# Altium schematic sheet AN-DGX - RN42.SchDoc("AN-DGX - RN42")
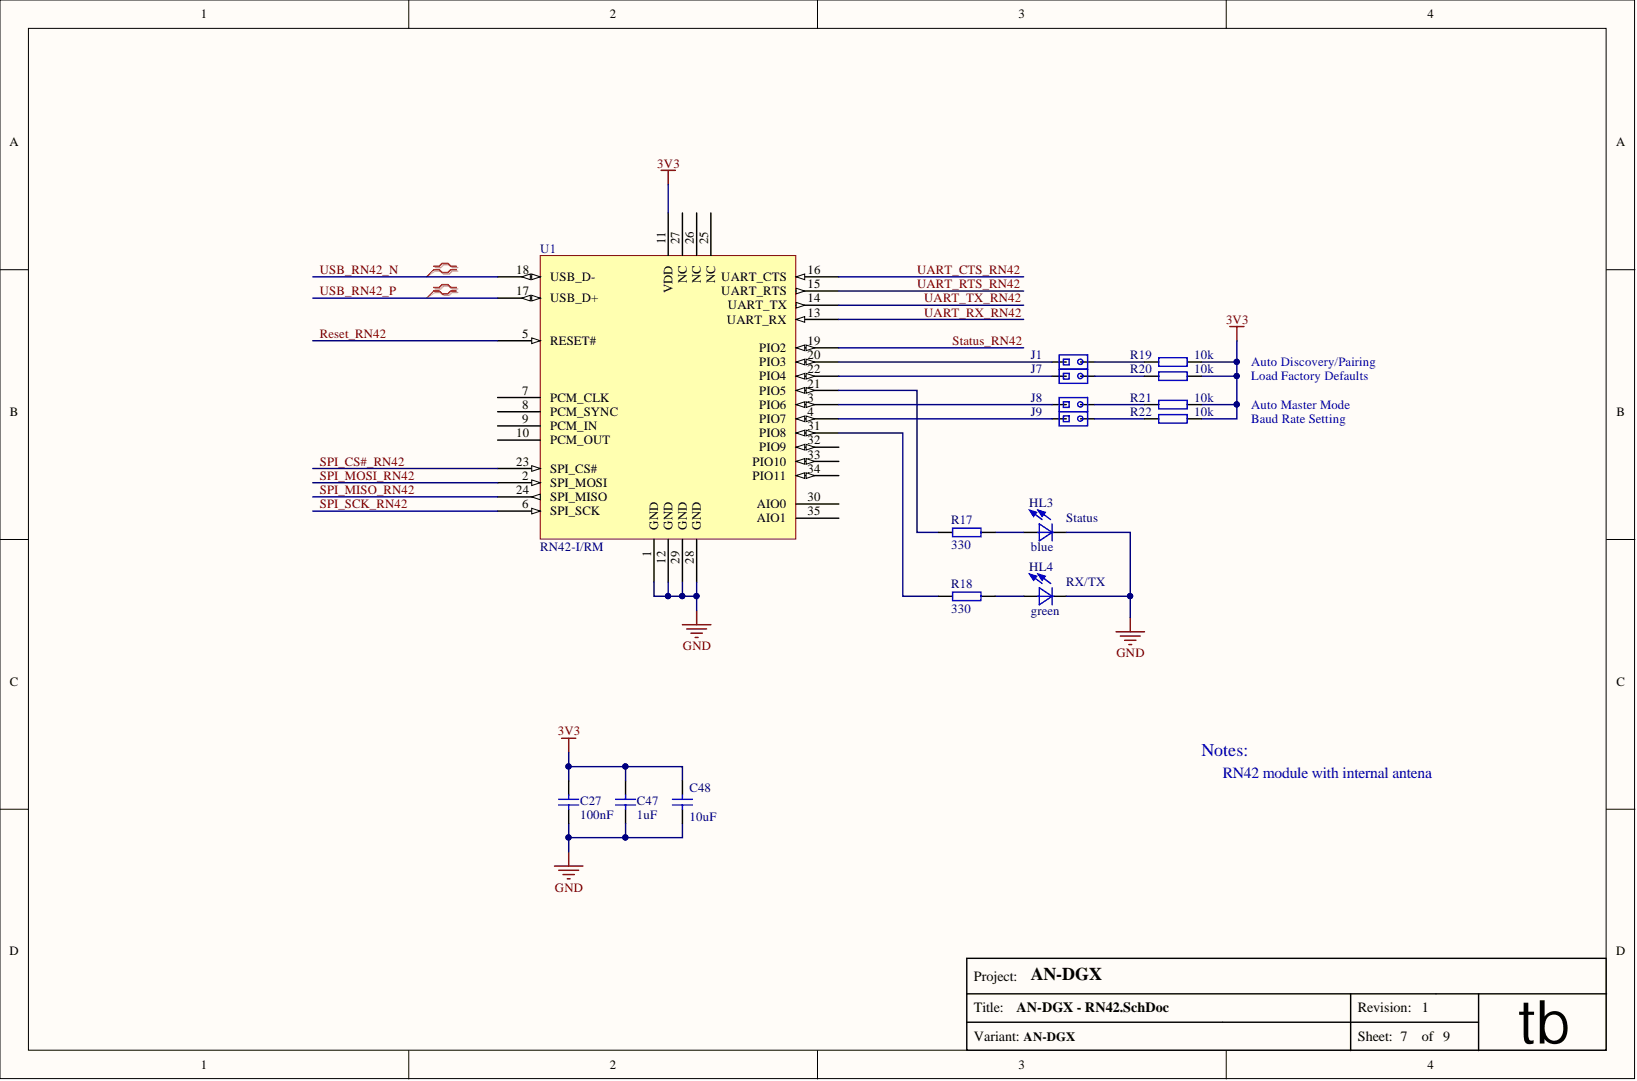
[diagram p0: AN-DGX - RN42.SchDoc]

## Parts
C27  value 100nF  [C0603]  (hidden comment: CAP)
C47  value 1uF  [C0603]  (hidden comment: CAP)
C48  value 10uF  [C0805]  (hidden comment: CAP)
HL3  Status  value blue  [0805_LED_blue]
HL4  RX/TX  value green  [0805_LED_green]
J1  (no value)  [Jumper_smd_d3]  (no BOM)
J7  (no value)  [Jumper_smd_d3]  (no BOM)
J8  (no value)  [Jumper_smd_d3]  (no BOM)
J9  (no value)  [Jumper_smd_d3]  (no BOM)
R17  value 330  [0603_RESISTOR]  (hidden comment: Resistor)
R18  value 330  [0603_RESISTOR]  (hidden comment: Resistor)
R19  value 10k  [0603_RESISTOR]  (hidden comment: Resistor)
R20  value 10k  [0603_RESISTOR]  (hidden comment: Resistor)
R21  value 10k  [0603_RESISTOR]  (hidden comment: Resistor)
R22  value 10k  [0603_RESISTOR]  (hidden comment: Resistor)
U1  RN42  value RN42-I/RM  [RN42-LAND]

## Nets
3V3: C27.2 C47.2 C48.2 R19.1 R20.1 R21.1 R22.1 U1.11(VDD)
GND: C27.1 C47.1 C48.1 HL3.2 HL4.2 U1.1(GND) U1.12(GND) U1.28(GND) U1.29(GND)
Reset_RN42: U1.5(RESET#)
SPI_CS#_RN42: U1.23(SPI_CS#)
SPI_MISO_RN42: U1.24(SPI_MISO)
SPI_MOSI_RN42: U1.2(SPI_MOSI)
SPI_SCK_RN42: U1.6(SPI_SCK)
Status_RN42: U1.19(PIO2)
UART_CTS_RN42: U1.16(UART_CTS)
UART_RTS_RN42: U1.15(UART_RTS)
UART_RX_RN42: U1.13(UART_RX)
UART_TX_RN42: U1.14(UART_TX)
USB_RN42_N: U1.18(USB_D-)
USB_RN42_P: U1.17(USB_D+)
NetHL3_1: HL3.1 R17.2
NetHL4_1: HL4.1 R18.2
NetJ1_1: J1.1 U1.20(PIO3)
NetJ1_2: J1.2 R19.2
NetJ7_1: J7.1 U1.22(PIO4)
NetJ7_2: J7.2 R20.2
NetJ8_1: J8.1 U1.3(PIO6)
NetJ8_2: J8.2 R21.2
NetJ9_1: J9.1 U1.4(PIO7)
NetJ9_2: J9.2 R22.2
NetR17_1: R17.1 U1.21(PIO5)
NetR18_1: R18.1 U1.31(PIO8)

Not connected: U1 7(PCM_CLK) 8(PCM_SYNC) 9(PCM_IN) 10(PCM_OUT) 25(NC) 26(NC) 27(NC) 30(AIO0) 32(PIO9) 33(PIO10) 34(PIO11) 35(AIO1)

## Library data of the parts
C27 = CAP: Manufacturer Part: VJ0603Y104JXJPW1BC; Manufacturer: Vishay / Vitramon; Type of Component: Ceramic Capacitor; Supplier 3 Part: 001832; Supplier 3 Link: http://voron.ua/catalog/001832; Supplier 3: http://voron.ua; Supplier 2 Part: 182925; Supplier 2 Link: http://imrad.com.ua/ru/cc0603krx7r8bb104; Supplier 2: http://imrad.com.ua/; Supplier 1 Link: aliexpress.com/item/100pcs-lot-100nF-X7R-Error-10-50V-0603-smd-capacitor/32318089455.html?spm=2114.03010208.3.2.PDNk2A&ws_ab_test=searchweb201556_8,searchweb201602_1_10065_10068_112_10069_110_111_418_10017_109_108_10060_10061_10062_10057_10039_10056_10055_10054_10059_10058_10073_10070_10052_10053_10050_10051,searchweb201603_1&btsid=c39062ca-3db8-4562-8db0-c782461646c7; Supplier 1: aliexpress.com; Package / Case: 0603; Manufacturer Link: http://www.vishay.com/product?docid=28548; Component Kind: Standard; Library Name: RPI-VITA.IntLib
C47 = CAP: Type of Component: Ceramic Capacitor; Supplier 2 Part: 183765; Supplier 2 Link: http://imrad.com.ua/ru/grm188r61e105ka12d; Supplier 2: http://imrad.com.ua; Supplier 1 Link: http://www.aliexpress.com/item/100pcs-lot-1uF-X5R-Error-10-16V-0603-smd-capacitor/32319876550.html?spm=2114.01010208.3.2.BIShJJ&ws_ab_test=searchweb201556_1,searchweb201602_2_505_506_503_504_301_10020_502_10001_10002_10017_10010_10005_10006_10011_10003_10021_10004_10022_10009_10008_10018_10019,searchweb201603_2&btsid=9f806633-6532-4f2c-9489-d7a06659a4dd; Supplier 1: aliexpress.com; Package / Case: 0603; Component Kind: Standard; Library Name: RPI-VITA.IntLib
C48 = CAP: Manufacturer Part: GRM21BC81C106KE15L; Manufacturer: Murata; Type of Component: Ceramic Capacitor; Supplier 2 Part: 189682; Supplier 2 Link: http://imrad.com.ua/ru/cc0805zry5v8bb105; Supplier 2: http://imrad.com.ua; Supplier 1 Link: aliexpress.com/store/product/100pcs-lot-10uF-X7R-Error-10-25V-0805-smd-capacitor/506373_32319279591.html?detailNewVersion=&categoryId=141908&spm=2114.12010208.1000023.190.luw8Z7; Supplier 1: aliexpress.com; Package / Case: 0805; Manufacturer Link: http://psearch.en.murata.com/capacitor/result/smd/?status=all&pid=GRM21BC81C106KE15L; Component Kind: Standard; Library Name: RPI-VITA.IntLib
HL3 = LED: Manufacturer Part: 0805 Led Blue; Type of Component: Led; Supplier Part: 0805 Led Blue; Supplier Link: aliexpress.com/store/product/FREE-SHIPPING-1000PCS-0805-2012-Blue-Clear-Ultra-Bright-SMD-LED-Indication/102034_32593006615.html?storeId=102034&spm=2114.12010208.100007.33.hkzVBq; Supplier: aliexpress.com; Package / Case: 0805; Component Kind: Standard; Library Name: RPI-VITA.IntLib
HL4 = LED: Manufacturer Part: 0805 Led Green; Type of Component: Led; Supplier Part: 0805 Led Green; Supplier Link: aliexpress.com/store/product/FREE-SHIPPING-1000PCS-0805-2012-Green-Clear-Ultra-Bright-SMD-LED-Indication/102034_32595423201.html?storeId=102034&spm=2114.12010208.100007.36.H0BBkE; Supplier: aliexpress.com; Package / Case: 0805; Component Kind: Standard; Library Name: RPI-VITA.IntLib
J1 J7-J9 = jumper_2pin_pads: Library Name: RPI-VITA.IntLib
R17 R18 = Resistor: Manufacturer Part: 0603 330; Manufacturer: UniOhm; Type of Component: Resistor; Supplier 2 Part: 181872; Supplier 2 Link: http://imrad.com.ua/ru/res-0603-330r-1; Supplier 2: http://imrad.com.ua/; Supplier 1 Part: 0603 330; Supplier 1 Link: aliexpress.com/store/product/0603-SMD-Resistor-1-330-ohm-5000pcs-lot/506373_32307375865.html?detailNewVersion=&categoryId=400503&spm=2114.12010208.1000023.227.iOPgvR; Supplier 1: aliexpress.com; Package / Case: 0603; Component Kind: Standard; Library Name: RPI-VITA.IntLib
R19-R22 = Resistor: Manufacturer Part: CRCW060310K0FKEA; Manufacturer: Vishay / Dale; Type of Component: Resistor; Supplier 3 Part: (0603) 10 kom; Supplier 3 Link: http://www.kosmodrom.com.ua/prodlist.php?page=0&name=%280603%29+10+kom&okbutton=%CF%EE%E8%F1%EA+%EF%EE+%F1%EA%EB%E0%E4%F3; Supplier 3: http://www.kosmodrom.com.ua; Supplier 2 Part: 183440; Supplier 2 Link: http://imrad.com.ua/ru/rc0603jr-0710kl; Supplier 2: http://imrad.com.ua/; Supplier 1 Part: 0603 10k; Supplier 1 Link: aliexpress.com/store/product/0603-SMD-Resistor-5-10K-ohm-5000pcs-lot/506373_32307351301.html?storeId=506373&spm=2114.12010208.100007.103.XAQnpm; Supplier 1: aliexpress.com; Package / Case: 0603; Manufacturer Link: http://www.vishay.com/product?docid=20035; Component Kind: Standard; Library Name: RPI-VITA.IntLib
U1 = RN42: Class 2 Bluetooth® Module with EDR Support; Manufacturer: Microchip; Type of Component: Bluetooth Module; Supplier 2 Part: RN42HID-I/RM-ND; Supplier 2 Link: https://www.digikey.com/product-detail/en/microchip-technology/RN42HID-I-RM/RN42HID-I-RM-ND/3597485; Supplier 2: Digi-Key; Supplier 1 Part: 765-RN-42; Supplier 1 Link: www.mouser.com/ProductDetail/Microchip-Technology/RN42-I-RM/?qs=sGAEpiMZZMskUkxWo%2fqA8rA3O%252bdGX9Mp; Supplier 1: mouser.com; Package / Case: Module; Manufacturer Part: RN42; Manufacturer Link: http://www.microchip.com/wwwproducts/en/RN42; Component Kind: Standard; Library Name: RPI-VITA.IntLib
